# Altium schematic sheet Comp Clipper.SchDoc
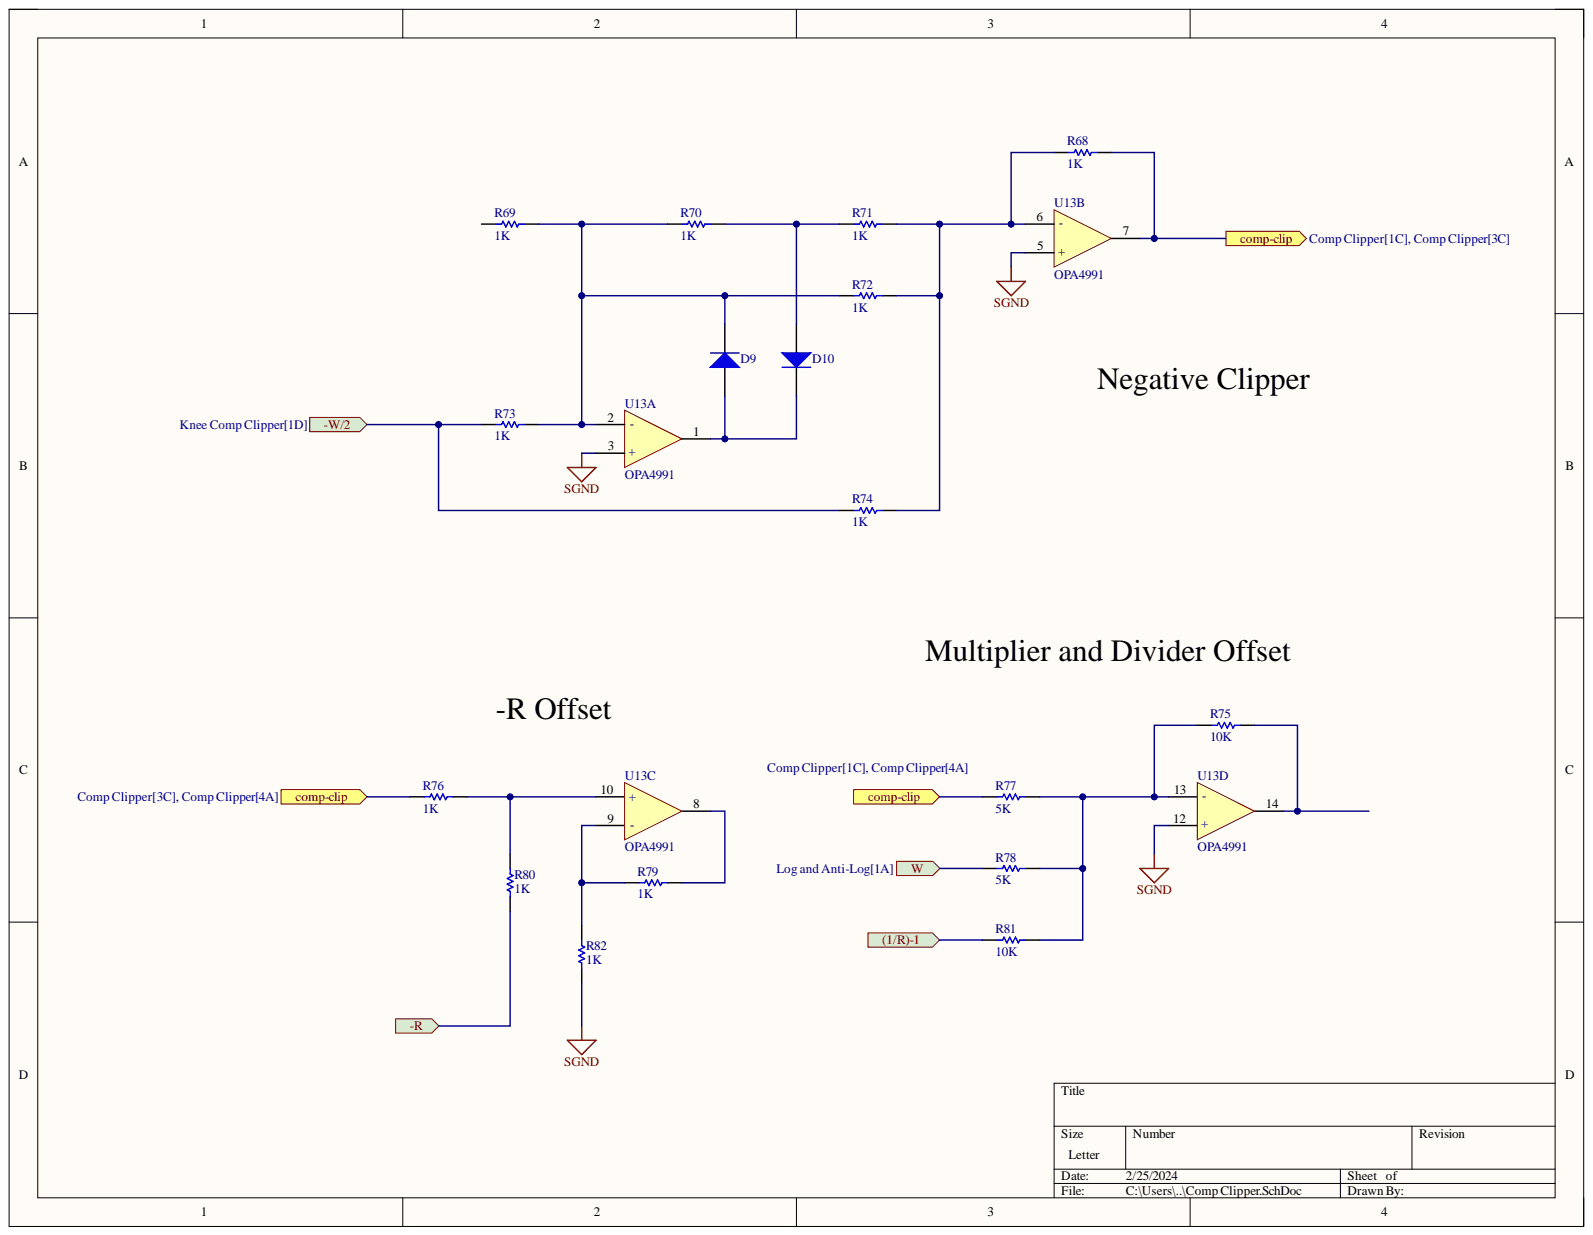
[diagram p0: Comp Clipper.SchDoc]

## Parts
D9  Diode  (value not drawn)
D10  Diode  (value not drawn)
R68  value 1K  (hidden comment: Resistor)
R69  value 1K  (hidden comment: Resistor)
R70  value 1K  (hidden comment: Resistor)
R71  value 1K  (hidden comment: Resistor)
R72  value 1K  (hidden comment: Resistor)
R73  value 1K  (hidden comment: Resistor)
R74  value 1K  (hidden comment: Resistor)
R75  value 10K  (hidden comment: Resistor)
R76  value 1K  (hidden comment: Resistor)
R77  value 5K  (hidden comment: Resistor)
R78  value 5K  (hidden comment: Resistor)
R79  value 1K  (hidden comment: Resistor)
R80  value 1K  (hidden comment: Resistor)
R81  value 10K  (hidden comment: Resistor)
R82  value 1K  (hidden comment: Resistor)
U13  OPA4991  [FP-D0014A-IPC_B]  (parts A,B,C,D)

## Ports
(1/R)-1, -R, -W/2, comp-clip, W

## Nets
SGND: R82.2 U13.3(+) U13.5(+) U13.12(+)
(1/R)-1: R81.1 ; port (1/R)-1
-R: R80.1 ; port -R
-W/2: R73.1 R74.1 ; port -W/2
comp-clip: R68.2 R76.1 R77.1 U13.7 ; port comp-clip
W: R78.2 ; port W
NetD9_1: D9.1 D10.2 U13.1
NetD9_2: D9.2 R69.2 R70.1 R72.1 R73.2 U13.2(-)
NetD10_1: D10.1 R70.2 R71.1
NetR68_1: R68.1 R71.2 R72.2 R74.2 U13.6(-)
NetR75_1: R75.1 U13.14
NetR75_2: R75.2 R77.2 R78.1 R81.2 U13.13(-)
NetR76_2: R76.2 R80.2 U13.10(+)
NetR79_1: R79.1 U13.8
NetR79_2: R79.2 R82.1 U13.9(-)

Not connected: R69 1

## Library data of the parts
D9 D10 = Diode: AF: 1; BV: 0; BV_MAX: 1e99; EG: 1.11; FC: 0.5; IBV: 0.001; IS: 1e-14; MJSW: 0.33; NTUN: 30; SimulationGenericComponent: True; TNOM: 27; XTI: 3; CJO: 0; CJP: 0; Component Kind: Standard; CTA: 0; CTP: 0; Description: Diode; FCS: 0.5; FV_MAX: 1e99; IKF: 0; IKR: 0; ISR: 1e-14; JSW: 0; JTUN: 0; JTUNSW: 0; KEG: 1; KF: 0; LEVEL: 1; Library Name: Simulation Generic Components.IntLib; N: 1; NBV: 1; NR: 1; NS: 1; PHP: 1; RS: 0; Simulation: diode; TCV: 0; TLEV: 0; TLEVC: 0; TM1: 0; TM2: 0; TPB: 0; TPHP: 0; TRS: 0; TRS2: 0; TT: 0; TTT1: 0; TTT2: 0; VJ: 1; XTITUN: 3
R68-R82 = Resistor: SimulationGenericComponent: True; TC1: 0; Component Kind: Standard; Description: Resistor; Library Name: Simulation Generic Components.IntLib; Simulation: R_Resistor_Default; TC2: 0
U13 = OPA4991IDR: 40-V, DUAL 4.5MHZ, RAIL-TO-RAIL; Component Kind: Standard; Library Name: DRC.SchLib
